# Altium schematic sheet IF_RX.SchDoc
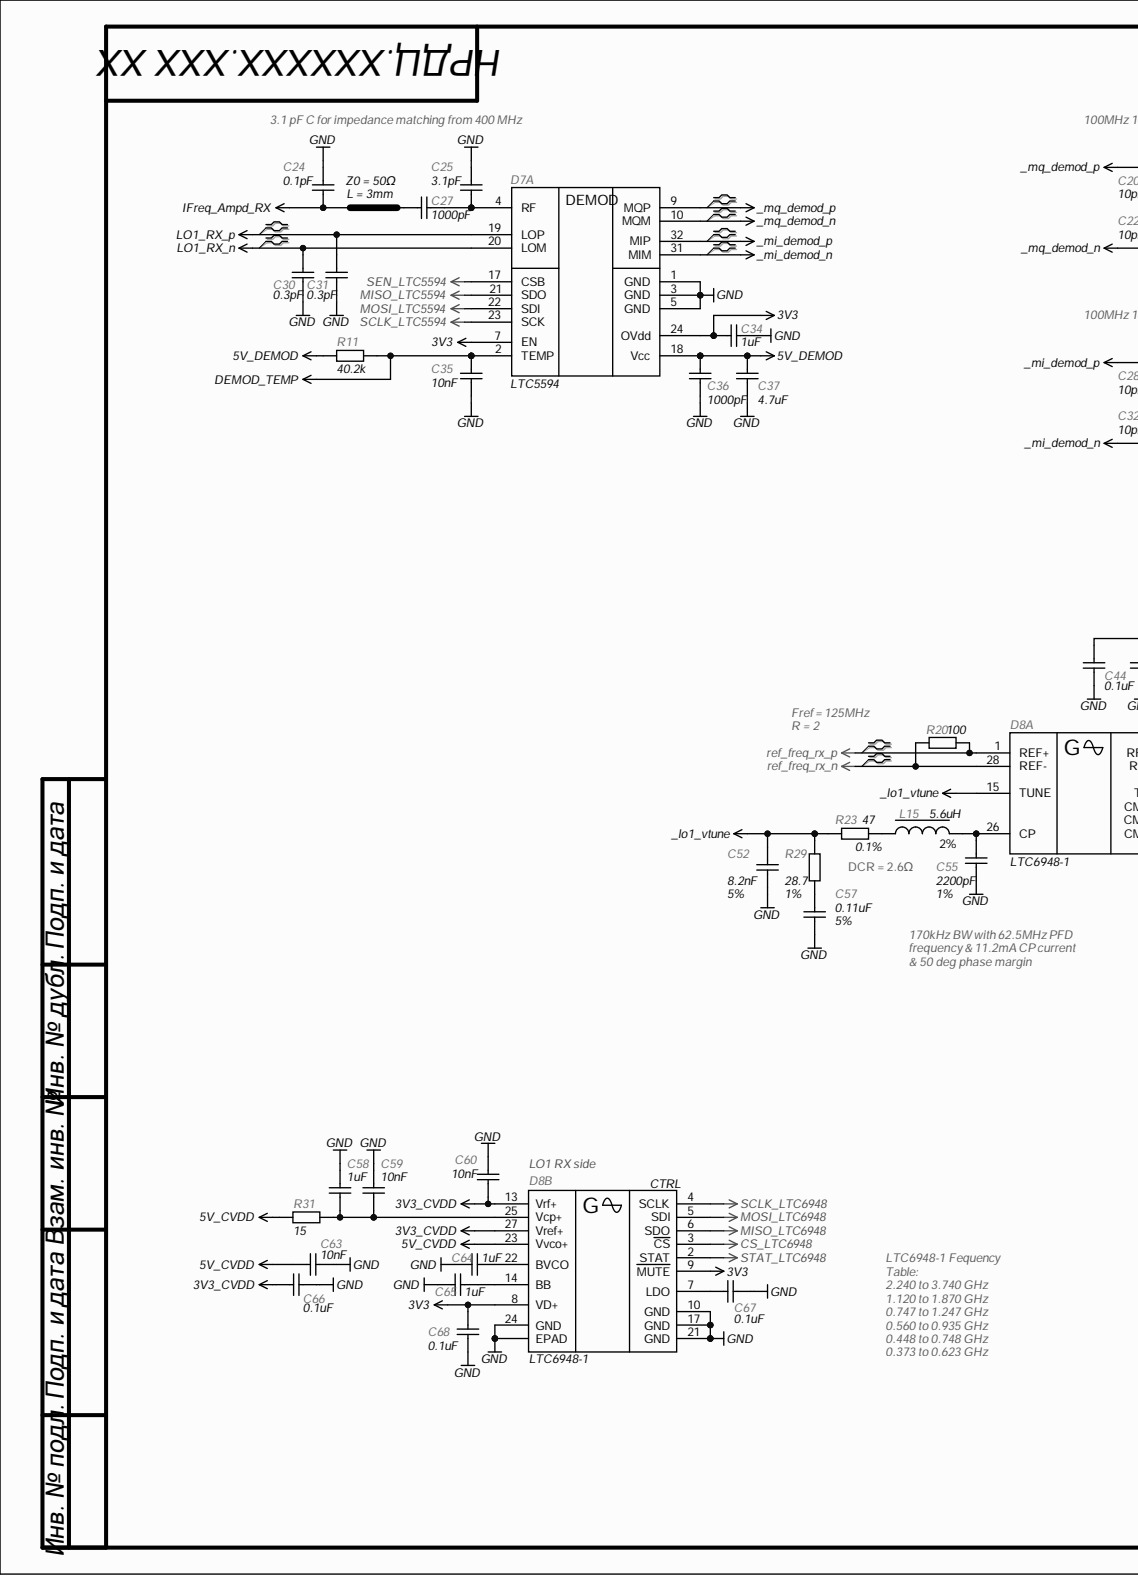
[diagram p0: IF_RX.SchDoc, left]
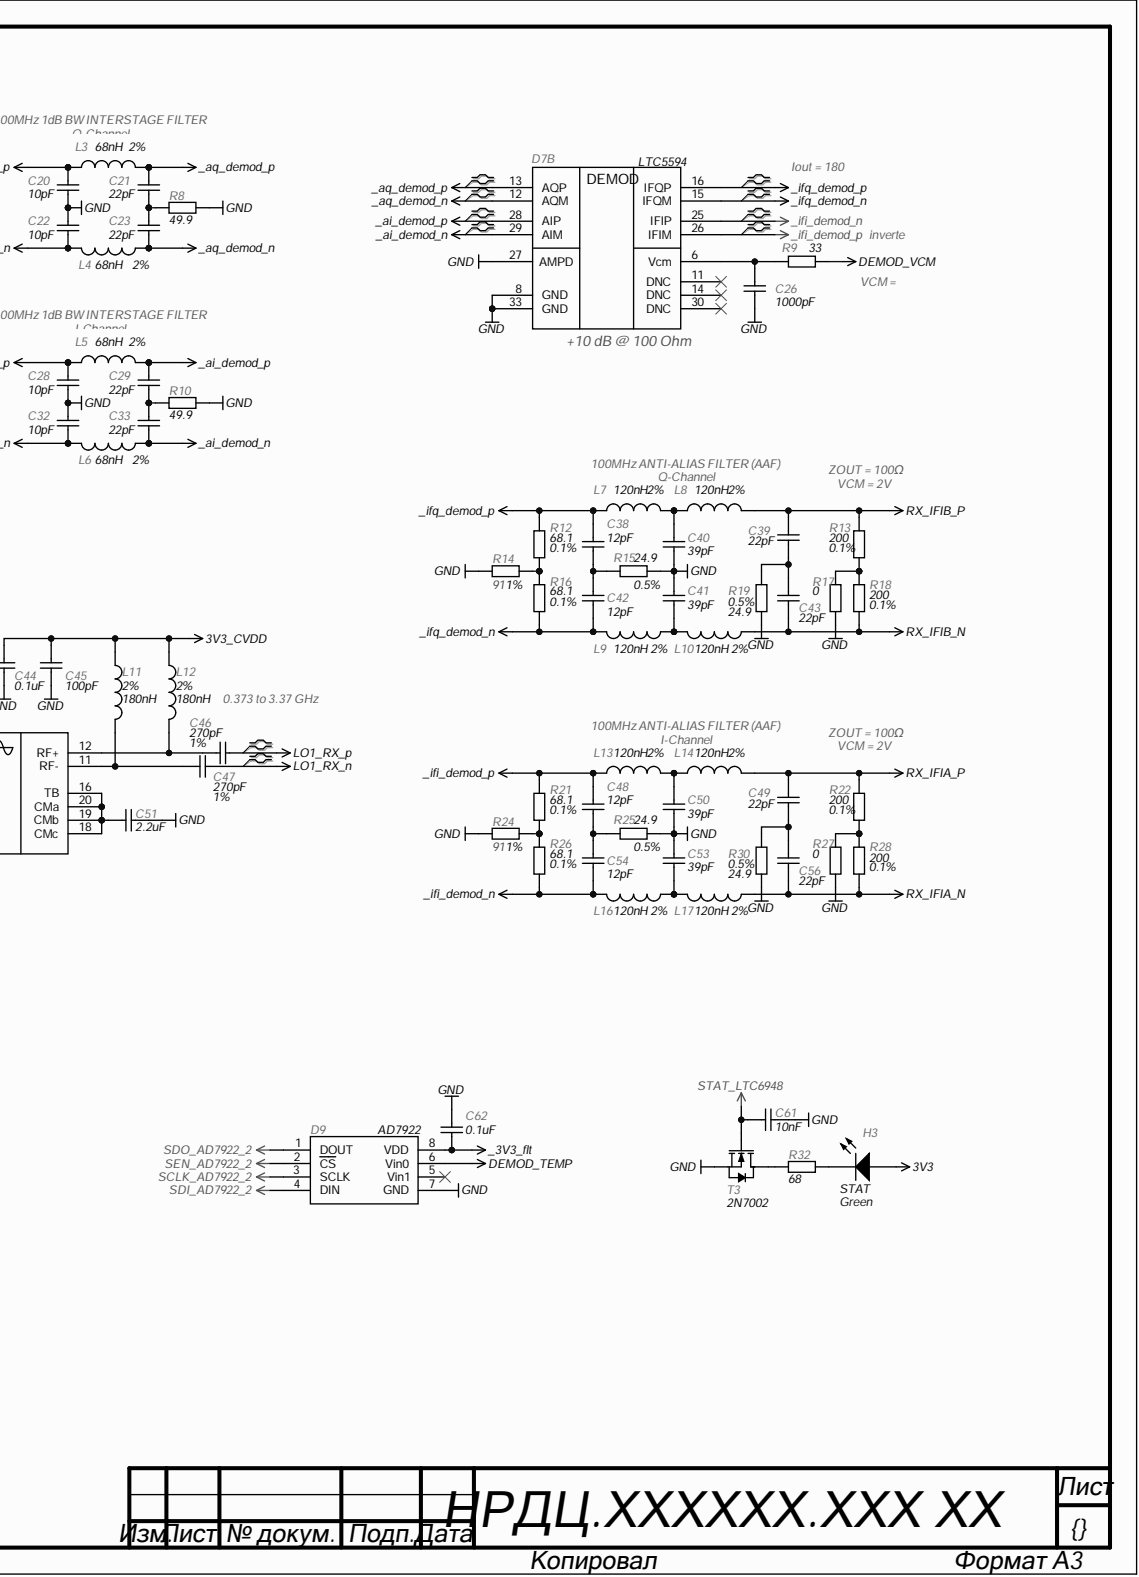
[diagram p1: IF_RX.SchDoc, right]

## Parts
C20  value 10pF  [C0402]  (hidden comment: CAP)
C21  value 22pF  [C0402]  (hidden comment: CAP)
C22  value 10pF  [C0402]  (hidden comment: CAP)
C23  value 22pF  [C0402]  (hidden comment: CAP)
C24  value 0.1pF  [C0402]  (hidden comment: CAP)
C25  value 3.1pF  [C0402]  (hidden comment: CAP)
C26  value 1000pF  [C0402]  (hidden comment: CAP)
C27  value 1000pF  [C0402]  (hidden comment: CAP)
C28  value 10pF  [C0402]  (hidden comment: CAP)
C29  value 22pF  [C0402]  (hidden comment: CAP)
C30  value 0.3pF  [C0402]  (hidden comment: CAP)
C31  value 0.3pF  [C0402]  (hidden comment: CAP)
C32  value 10pF  [C0402]  (hidden comment: CAP)
C33  value 22pF  [C0402]  (hidden comment: CAP)
C34  value 1uF  [C0402]  (hidden comment: CAP)
C35  value 10nF  [C0402]  (hidden comment: CAP)
C36  value 1000pF  [C0402]  (hidden comment: CAP)
C37  value 4.7uF  [C0805]  (hidden comment: CAP)
C38  value 12pF  [C0402]  (hidden comment: CAP)
C39  value 22pF  [C0402]  (hidden comment: CAP)
C40  value 39pF  [C0402]  (hidden comment: CAP)
C41  value 39pF  [C0402]  (hidden comment: CAP)
C42  value 12pF  [C0402]  (hidden comment: CAP)
C43  value 22pF  [C0402]  (hidden comment: CAP)
C44  value 0.1uF  [C0402]  (hidden comment: CAP)
C45  value 100pF  [C0402]  (hidden comment: CAP)
C46  value 270pF  Tolerance: 1%  [C0402]  (hidden comment: CAP)
C47  value 270pF  Tolerance: 1%  [C0402]  (hidden comment: CAP)
C48  value 12pF  [C0402]  (hidden comment: CAP)
C49  value 22pF  [C0402]  (hidden comment: CAP)
C50  value 39pF  [C0402]  (hidden comment: CAP)
C51  value 2.2uF  [C0603]  (hidden comment: CAP)
C52  value 8.2nF  Tolerance: 5%  [C0603]  (hidden comment: CAP)
C53  value 39pF  [C0402]  (hidden comment: CAP)
C54  value 12pF  [C0402]  (hidden comment: CAP)
C55  value 2200pF  Tolerance: 1%  [C0603]  (hidden comment: CAP)
C56  value 22pF  [C0402]  (hidden comment: CAP)
C57  value 0.11uF  Tolerance: 5%  [C0805]  (hidden comment: CAP)
C58  value 1uF  [C0402]  (hidden comment: CAP)
C59  value 10nF  [C0402]  (hidden comment: CAP)
C60  value 10nF  [C0402]  (hidden comment: CAP)
C61  value 10nF  [C0402]  (hidden comment: CAP)
C62  value 0.1uF  [C0402]  (hidden comment: CAP)
C63  value 10nF  [C0402]  (hidden comment: CAP)
C64  value 1uF  [C0402]  (hidden comment: CAP)
C65  value 1uF  [C0402]  (hidden comment: CAP)
C66  value 0.1uF  [C0402]  (hidden comment: CAP)
C67  value 0.1uF  [C0402]  (hidden comment: CAP)
C68  value 0.1uF  [C0402]  (hidden comment: CAP)
D7  LTC5594  [QFN-32]  (parts A,B)
D8  LTC6948-1  [QFN-28]  (parts A,B)
D9  AD7922  [MSOP-8]
H3  STAT  Color: Green  [LED_0805_Green]
L3  value 68nH  Tolerance: 2%  [L0402HPH]  (hidden comment: Inductor RF)
L4  value 68nH  Tolerance: 2%  [L0402HPH]  (hidden comment: Inductor RF)
L5  value 68nH  Tolerance: 2%  [L0402HPH]  (hidden comment: Inductor RF)
L6  value 68nH  Tolerance: 2%  [L0402HPH]  (hidden comment: Inductor RF)
L7  value 120nH  Tolerance: 2%  [L0402HPH]  (hidden comment: Inductor RF)
L8  value 120nH  Tolerance: 2%  [L0402HPH]  (hidden comment: Inductor RF)
L9  value 120nH  Tolerance: 2%  [L0402HPH]  (hidden comment: Inductor RF)
L10  value 120nH  Tolerance: 2%  [L0402HPH]  (hidden comment: Inductor RF)
L11  value 180nH  Tolerance: 2%  [L0603_HP]  (hidden comment: Inductor RF)
L12  value 180nH  Tolerance: 2%  [L0603_HP]  (hidden comment: Inductor RF)
L13  value 120nH  Tolerance: 2%  [L0402HPH]  (hidden comment: Inductor RF)
L14  value 120nH  Tolerance: 2%  [L0402HPH]  (hidden comment: Inductor RF)
L15  value 5.6uH  Tolerance: 2%  [L1008_LS]  (hidden comment: Inductor)
L16  value 120nH  Tolerance: 2%  [L0402HPH]  (hidden comment: Inductor RF)
L17  value 120nH  Tolerance: 2%  [L0402HPH]  (hidden comment: Inductor RF)
R8  value 49.9  [R0402]  (hidden comment: RES)
R9  value 33  [R0402]  (hidden comment: RES)
R10  value 49.9  [R0402]  (hidden comment: RES)
R11  value 40.2k  [R0402]  (hidden comment: RES)
R12  value 68.1  Tolerance: 0.1%  [R0402]  (hidden comment: RES)
R13  value 200  Tolerance: 0.1%  [R0402]  (hidden comment: RES)
R14  value 91  Tolerance: 1%  [R0402]  (hidden comment: RES)
R15  value 24.9  Tolerance: 0.5%  [R0402]  (hidden comment: RES)
R16  value 68.1  Tolerance: 0.1%  [R0402]  (hidden comment: RES)
R17  value 0  [R0402]  (hidden comment: RES)
R18  value 200  Tolerance: 0.1%  [R0402]  (hidden comment: RES)
R19  value 24.9  Tolerance: 0.5%  [R0402]  (hidden comment: RES)
R20  value 100  [R0402]  (hidden comment: RES)
R21  value 68.1  Tolerance: 0.1%  [R0402]  (hidden comment: RES)
R22  value 200  Tolerance: 0.1%  [R0402]  (hidden comment: RES)
R23  value 47  Tolerance: 0.1%  [R0402]  (hidden comment: RES)
R24  value 91  Tolerance: 1%  [R0402]  (hidden comment: RES)
R25  value 24.9  Tolerance: 0.5%  [R0402]  (hidden comment: RES)
R26  value 68.1  Tolerance: 0.1%  [R0402]  (hidden comment: RES)
R27  value 0  [R0402]  (hidden comment: RES)
R28  value 200  Tolerance: 0.1%  [R0402]  (hidden comment: RES)
R29  value 28.7  [R0402]  (hidden comment: RES)
R30  value 24.9  Tolerance: 0.5%  [R0402]  (hidden comment: RES)
R31  value 15  [R0603]  (hidden comment: RES)
R32  value 68  [R0402]  (hidden comment: RES)
T3  2N7002  [SOT-23]

## Nets
3V3: C34.1 C68.2 D7.7(EN) D7.24(OVdd) D8.8(VD+) D8.9(MUTE) H3.2
3V3_CVDD: C44.2 C45.2 C60.2 C66.2 D8.13(Vrf+) D8.27(Vref+) L11.2 L12.2
5V_CVDD: C63.1 D8.23(Vvco+) R31.2
5V_DEMOD: C36.1 C37.2 D7.18(Vcc) R11.1
_3V3_flt: C62.1 D9.8(VDD)
_ai_demod_n: C33.2 D7.29(AIM) L6.2
_ai_demod_p: C29.1 D7.28(AIP) L5.2
_aq_demod_n: C23.2 D7.12(AQM) L4.2
_aq_demod_p: C21.1 D7.13(AQP) L3.2
_ifi_demod_n: C54.2 D7.25(IFIP) L16.1 R26.2
_ifi_demod_p: C48.1 D7.26(IFIM) L13.1 R21.1
_ifq_demod_n: C42.2 D7.15(IFQM) L9.1 R16.2
_ifq_demod_p: C38.1 D7.16(IFQP) L7.1 R12.1
_lo1_vtune: C52.2 D8.15(TUNE) R23.2 R29.1
_mi_demod_n: C32.2 D7.31(MIM) L6.1
_mi_demod_p: C28.1 D7.32(MIP) L5.1
_mq_demod_n: C22.2 D7.10(MQM) L4.1
_mq_demod_p: C20.1 D7.9(MQP) L3.1
CS_LTC6948: D8.3(CS)
DEMOD_TEMP: C35.1 D7.2(TEMP) D9.6(Vin0) R11.2
DEMOD_VCM: R9.1
GND: C20.2 C22.1 C24.2 C25.2 C26.2 C28.2 C30.2 C31.2 C32.1 C34.2 C35.2 C36.2 C37.1 C40.2 C41.1 C44.1 C45.1 C50.2 C51.1 C52.1 C53.1 C55.1 C57.1 C58.1 C59.1 C60.1 C61.2 C62.2 C63.2 C64.1 C65.2 C66.1 C67.1 C68.1 D7.1(GND) D7.3(GND) D7.5(GND) D7.8(GND) D7.27(AMPD) D7.33(GND) D8.10(GND) D8.17(GND) D8.21(GND) D8.24(GND) D8.29(EPAD) D9.7(GND) R8.2 R10.2 R14.1 R15.2 R17.2 R19.2 R24.1 R25.2 R27.2 R30.2 T3.2
IFreq_Ampd_RX: C24.1 C27.2
LO1_RX_n: C30.1 C47.2 D7.20(LOM)
LO1_RX_p: C31.1 C46.2 D7.19(LOP)
MISO_LTC5594: D7.21(SDO)
MISO_LTC6948: D8.6(SDO)
MOSI_LTC5594: D7.22(SDI)
MOSI_LTC6948: D8.5(SDI)
ref_freq_rx_n: D8.28(REF-) R20.1
ref_freq_rx_p: D8.1(REF+) R20.2
RX_IFIA_N: C56.2 L17.2 R28.2
RX_IFIA_P: C49.1 L14.2 R22.1
RX_IFIB_N: C43.2 L10.2 R18.2
RX_IFIB_P: C39.1 L8.2 R13.1
SCLK_AD7922_2: D9.3(SCLK)
SCLK_LTC5594: D7.23(SCK)
SCLK_LTC6948: D8.4(SCLK)
SDI_AD7922_2: D9.4(DIN)
SDO_AD7922_2: D9.1(DOUT)
SEN_AD7922_2: D9.2(CS)
SEN_LTC5594: D7.17(CSB)
STAT_LTC6948: C61.1 D8.2(STAT) T3.1
NetC21_2: C21.2 C23.1 R8.1
NetC25_1: C25.1 C27.1 D7.4(RF)
NetC26_1: C26.1 D7.6(Vcm) R9.2
NetC29_2: C29.2 C33.1 R10.1
NetC38_2: C38.2 C42.1 R15.1
NetC39_2: C39.2 C43.1 R19.1
NetC40_1: C40.1 L7.2 L8.1
NetC41_2: C41.2 L9.2 L10.1
NetC46_1: C46.1 D8.12(RF+) L12.1
NetC47_1: C47.1 D8.11(RF-) L11.1
NetC48_2: C48.2 C54.1 R25.1
NetC49_2: C49.2 C56.1 R30.1
NetC50_1: C50.1 L13.2 L14.1
NetC51_2: C51.2 D8.16(TB) D8.18(CMc) D8.19(CMb) D8.20(CMa)
NetC53_2: C53.2 L16.2 L17.1
NetC55_2: C55.2 D8.26(CP) L15.2
NetC57_2: C57.2 R29.2
NetC58_2: C58.2 C59.2 D8.25(Vcp+) R31.1
NetC64_2: C64.2 D8.22(BVCO)
NetC65_1: C65.1 D8.14(BB)
NetC67_2: C67.2 D8.7(LDO)
NetH3_1: H3.1 R32.2
NetL15_1: L15.1 R23.1
NetR12_2: R12.2 R14.2 R16.1
NetR13_2: R13.2 R17.1 R18.1
NetR21_2: R21.2 R24.2 R26.1
NetR22_2: R22.2 R27.1 R28.1
NetR32_1: R32.1 T3.3

Not connected: D7 11(DNC) 14(DNC) 30(DNC); D9 5(Vin1)

## Library data of the parts
C20 C22 C28 C32 = CAP: SMD Capacitor; Manufacturer: Murata; Material: NP0; P/N: GRM1555C1H100FA01D; Tolerance: 1%; Type: Ceramic Capacitor; Voltage: 50V; Component Kind: Standard; Supplier 1: Digi-key; Supplier 2: Mouser; Supplier 3: Farnell; Supplier Part Number 1: 490-6186-1-ND; Supplier Part Number 2: 81-GRM1555C1H100FA1D; Library Name: Kintex_SDR.SchLib
C21 C23 C29 C33 C39 C43 C49 C56 = CAP: SMD Capacitor; Manufacturer: Murata; Material: NP0; P/N: GRM1555C1H220FA01D; Tolerance: 1%; Type: Ceramic Capacitor; Voltage: 50V; Component Kind: Standard; Supplier 1: Digi-key; Supplier 2: Mouser; Supplier 3: Farnell; Supplier Part Number 1: 490-8589-1-ND; Supplier Part Number 2: 81-GRM1555C1H220FA1D; Library Name: Kintex_SDR.SchLib
C24 = CAP: SMD Capacitor; Manufacturer: Murata; Material: NP0; P/N: GRM1555C1HR10WA01D; Tolerance: ±0.05pF; Type: Ceramic Capacitor; Voltage: 50V; Component Kind: Standard; Supplier 1: Digi-key; Supplier 2: Mouser; Supplier 3: Farnell; Supplier Part Number 1: 490-6259-1-ND; Supplier Part Number 2: 81-GRM1555C1HR10WA1D; Library Name: Kintex_SDR.SchLib
C25 = CAP: SMD Capacitor; Manufacturer: Murata; Material: NP0; P/N: GJM1555C1H3R1WB01D; Tolerance: ±0.05pF; Type: Ceramic Capacitor; Voltage: 50V; Component Kind: Standard; Supplier 1: Digi-key; Supplier 2: Mouser; Supplier 3: Farnell; Supplier Part Number 1: 490-GJM1555C1H3R1WB01DCT-ND; Supplier Part Number 2: 81-GJM1555C1H3R1WB1D; Library Name: Kintex_SDR.SchLib
C26 C27 C36 = CAP: SMD Capacitor; Manufacturer: Murata; Material: NP0; P/N: GRM1555C1H102JA01D; Tolerance: 5%; Type: Ceramic Capacitor; Voltage: 50V; Component Kind: Standard; Supplier 1: Digi-key; Supplier 2: Mouser; Supplier 3: Farnell; Supplier Part Number 1: 490-3244-1-ND; Supplier Part Number 2: 81-GRM1555C1H102JA1D; Library Name: Kintex_SDR.SchLib
C30 C31 = CAP: SMD Capacitor; Manufacturer: Murata; Material: NP0; P/N: GRM1555C1HR30WA01D; Tolerance: ±0.05pF; Type: Ceramic Capacitor; Voltage: 50V; Component Kind: Standard; Supplier 1: Digi-key; Supplier 2: Mouser; Supplier 3: Farnell; Supplier Part Number 1: 490-6261-1-ND; Supplier Part Number 2: 81-GRM1555C1HR30WA1D; Library Name: Kintex_SDR.SchLib
C34 C58 C64 C65 = CAP: SMD Capacitor; Manufacturer: Murata; Material: X7R; P/N: GRM155R70J105KA12J; Tolerance: 10%; Type: Ceramic Capacitor; Voltage: 6.3V; Component Kind: Standard; Supplier 1: Digi-key; Supplier 2: Mouser; Supplier 3: Farnell; Supplier Part Number 1: 490-13339-1-ND; Supplier Part Number 2: 81-GRM155R70J105KA2J; Library Name: Kintex_SDR.SchLib
C35 C59-C61 C63 = CAP: SMD Capacitor; Manufacturer: Murata; Material: X7R; P/N: GRM155R71E103KA01D; Tolerance: 10%; Type: Ceramic Capacitor; Voltage: 25V; Component Kind: Standard; Supplier 1: Digi-key; Supplier 2: Mouser; Supplier 3: Farnell; Supplier Part Number 1: 490-1312-1-ND; Supplier Part Number 2: 81-GRM36X103K25; Library Name: Kintex_SDR.SchLib
C37 = CAP: SMD Capacitor; Manufacturer: Murata; Material: X5R; P/N: GRM21BR61H475KE51L; Tolerance: 10%; Type: Ceramic Capacitor; Voltage: 50V; Component Kind: Standard; Supplier 1: Digi-key; Supplier 2: Mouser; Supplier 3: Farnell; Supplier Part Number 1: 490-10751-1-ND; Supplier Part Number 2: 81-GRM21BR61H475KE1L; Library Name: Kintex_SDR.SchLib
C38 C42 C48 C54 = CAP: SMD Capacitor; Manufacturer: Murata; Material: NP0; P/N: GRM1555C1H120FA01D; Tolerance: 1%; Type: Ceramic Capacitor; Voltage: 50V; Component Kind: Standard; Supplier 1: Digi-key; Supplier 2: Mouser; Supplier 3: Farnell; Supplier Part Number 1: 490-6196-1-ND; Supplier Part Number 2: 81-GRM1555C1H120FA1D; Library Name: Kintex_SDR.SchLib
C40 C41 C50 C53 = CAP: SMD Capacitor; Manufacturer: Murata; Material: NP0; P/N: GRM1555C1H390FA01D; Tolerance: 1%; Type: Ceramic Capacitor; Voltage: 50V; Component Kind: Standard; Supplier 1: Digi-key; Supplier 2: Mouser; Supplier 3: Farnell; Supplier Part Number 1: 490-9663-1-ND; Supplier Part Number 2: 81-GRM1555C1H390FA1D; Library Name: Kintex_SDR.SchLib
C44 C62 C66-C68 = CAP: SMD Capacitor; Manufacturer: Murata; Material: X7R; P/N: GRM155R71A104KA01J; Tolerance: 10%; Type: Ceramic Capacitor; Voltage: 10V; Component Kind: Standard; Supplier 1: Digi-key; Supplier 2: Mouser; Supplier 3: Farnell; Supplier Part Number 1: 490-6322-1-ND; Supplier Part Number 2: 81-GRM155R71A104KA1J; Library Name: Kintex_SDR.SchLib
C45 = CAP: SMD Capacitor; Manufacturer: Murata; Material: NP0; P/N: GRM1555C1H101FA01D; Tolerance: 1%; Type: Ceramic Capacitor; Voltage: 50V; Component Kind: Standard; Supplier 1: Digi-key; Supplier 2: Mouser; Supplier 3: Farnell; Supplier Part Number 1: 490-8180-1-ND; Supplier Part Number 2: 81-GRM1555C1H101FA1D; Library Name: Kintex_SDR.SchLib
C46 C47 = CAP: SMD Capacitor; Manufacturer: Murata; Material: NP0; P/N: GRM1555C1H271FA01D; Type: Ceramic Capacitor; Voltage: 50V; Component Kind: Standard; Supplier 1: Digi-key; Supplier 2: Mouser; Supplier 3: Farnell; Supplier Part Number 1: 490-10686-1-ND; Supplier Part Number 2: 81-GRM1555C1H271FA1D; Library Name: Kintex_SDR.SchLib
C51 = CAP: SMD Capacitor; Manufacturer: Murata; Material: X7R; P/N: GRM188R71A225KE15J; Tolerance: 10%; Type: Ceramic Capacitor; Voltage: 10V; Component Kind: Standard; Supplier 1: Digi-key; Supplier 2: Mouser; Supplier 3: Farnell; Supplier Part Number 1: 490-6418-1-ND; Supplier Part Number 2: 81-GRM188R71A225KE5J; Library Name: Kintex_SDR.SchLib
C52 = CAP: SMD Capacitor; Manufacturer: Murata; Material: NP0; P/N: GRM1885C1H822JA01D; Type: Ceramic Capacitor; Voltage: 50V; Component Kind: Standard; Supplier 1: Digi-key; Supplier 2: Mouser; Supplier 3: Farnell; Supplier Part Number 1: 490-11482-1-ND; Supplier Part Number 2: 81-GRM1885C1H822JA1D; Library Name: Kintex_SDR.SchLib
C55 = CAP: SMD Capacitor; Manufacturer: Murata; Material: NP0; P/N: GCM1885C1H222FA16D; Type: Ceramic Capacitor; Voltage: 50V; Component Kind: Standard; Supplier 1: Digi-key; Supplier 2: Mouser; Supplier 3: Farnell; Supplier Part Number 1: 490-16421-1-ND; Supplier Part Number 2: 81-GCM1885C1H222FA6D; Library Name: Kintex_SDR.SchLib
C57 = CAP: SMD Capacitor; Manufacturer: Murata; Material: NP0; P/N: GRM2195C1H113JA01D; Type: Ceramic Capacitor; Voltage: 50V; Component Kind: Standard; Supplier 1: Digi-key; Supplier 2: Mouser; Supplier 3: Farnell; Supplier Part Number 1: 490-8297-1-ND; Supplier Part Number 2: 81-GRM2195C1H113JA1D; Library Name: Kintex_SDR.SchLib
D7 = LTC5594: Quadrature Demodulator; Manufacturer: Analog Devices; P/N: LTC5594IUH#PBF; Type: High Linearity  I/Q Demodulator; Component Kind: Standard; Library Name: DBLib.SchLib; Name: LTC5594; Package: 32-Lead 5mm × 5mm QFN; Supplier 1: Digi-Key; Supplier 2: Mouser; Supplier 3: Farnell; Supplier Part Number 1: LTC5594IUH#TRPBF-ND; Supplier Part Number 2: 584-LTC5594IUHPBF; Supplier Part Number 3: 4034017; Temperature Range: –40°C to 125°C
D8 = LTC6948: The LTC®LTC6948 is a high performance, low noise, 6.39GHz  phase-locked loop (PLL); Manufacturer: Linear Technology; P/N: LTC6948IUFD-1; Type: Clock/Frequency Synthesizer (RF/IF), Integer N; Voltage: 3.15 V ~ 5.25 V; Component Kind: Standard; Library Name: DBLib.SchLib; Name: LTC6948-1; Package: 28-QFN (4x5); Supplier 1: Digi-Key; Supplier 2: Mouser; Supplier 3: Farnell; Supplier Part Number 1: 505-LTC6948IUFD-1#TRPBFCT-ND; Supplier Part Number 2: 584-LTC6948IUFD-1PBF; Temperature Range: -40°C ~ 105°C
D9 = AD79x2: 1 MSPS, 10-/12-Bit ADC; Manufacturer: Analog Device; Fast throughput rate: 1MHz; High speed serial interface: SPI®/QSPI™/MICROWIRE™/DSP compatible; Name: AD7922ARM; P/N: AD7922ARMZ; Type: 2-Channel, 2.35 V to 5.25 V,1 MSPS, 10-/12-Bit ADC; Voltage: 2.35 V to 5.25 V; Component Kind: Standard; Package: MSOP-8; Supplier 1: Digi-key; Supplier 2: Mouser; Supplier 3: Farnell; Supplier Part Number 1: 505-AD7922ARMZ-ND; Supplier Part Number 2: 584-AD7922ARMZ; Value: AD7922; Library Name: Kintex_SDR.SchLib
H3 = LED: CHIP LED LAMP; Manufacturer: Kingbright; Name: APHCM2012; P/N: APHCM2012CGCK-F01; Component Kind: Standard; Library Name: DBLib.SchLib; Package: 2.0 x 1.25 mm SMD; Supplier 1: Digi-Key; Supplier 2: Mouser; Supplier 3: Farnell; Supplier Part Number 1: 754-1094-1-ND; Supplier Part Number 2: 604-APHCM2012CGCK; Type: CHIP LED LAMP
L3-L6 = Inductor RF: Chip SMD Inductor; Manufacturer: Coilcraft; Current: 320mA; Frequency: 1.84GHz; Name: 0402HPH-68NXGL; P/N: 0402HPH-68NXGLW; Component Kind: Standard; Library Name: DBLib.SchLib; Series: 0402HP; Supplier 1: Digi-key; Supplier 2: Mouser; Supplier 3: Farnell; Supplier Part Number 2: 994-0402HPH-68NXGLW; Supplier Part Number 3: 2285859; Temperature Range: -25…85°C
L7-L10 L13 L14 L16 L17 = Inductor RF: Chip SMD Inductor; Manufacturer: Coilcraft; Current: 310mA; Frequency: 1.25GHz; Name: 0402HPH-R12XGL; P/N: 0402HPH-R12XGLW; Component Kind: Standard; Library Name: DBLib.SchLib; Series: 0402HP; Supplier 1: Digi-key; Supplier 2: Mouser; Supplier 3: Farnell; Supplier Part Number 2: 994-0402HPH-R12XGLW; Supplier Part Number 3: 2285863; Temperature Range: -25…85°C
L11 L12 = Inductor RF: Chip SMD Inductor; Manufacturer: Coilcraft; Current: 310mA; Frequency: 1.5GHz; Name: 0603HP-R18XG; P/N: 0603HP-R18XGL; Component Kind: Standard; Library Name: DBLib.SchLib; Series: 0603HP; Supplier 1: Digi-key; Supplier 2: Mouser; Supplier 3: Farnell; Supplier Part Number 2: 994-0603HP-R18XGLW; Supplier Part Number 3: 2286173; Temperature Range: -25…85°C
L15 = Inductor: Chip SMD Inductor; Manufacturer: Coilcraft; Current: 240mA; DCR: 1.82Ω; Frequency: 32MHz; Name: 1008LS; P/N: 1008LS-562XJLC; Component Kind: Standard; Library Name: DBLib.SchLib; Series: 1008LS; Supplier 1: Digi-key; Supplier 2: Mouser; Supplier 3: Farnell; Supplier Part Number 2: 994-1008LS-562XJLC; Supplier Part Number 3: 2286847; Temperature Range: -25…85°C
R8 R10 = RES: SMD Resistor; Manufacturer: Panasonic Electronic Components; Name: Chip SMD Resistor; P/N: ERJ-2RKD49R9X; Power: 0.063 Wt; Tolerance: 0.5%; Component Kind: Standard; Supplier 1: Digi-key; Supplier 2: Mouser; Supplier 3: Farnell; Supplier Part Number 1: P15987CT-ND; Supplier Part Number 2: 667-ERJ-2RKD49R9X; Temperature Range: -55…155°C; Library Name: Kintex_SDR.SchLib
R9 = RES: SMD Resistor; Name: Chip SMD Resistor; P/N: ERJ-2RKF33R0X; Power: 0.1 Wt; Tolerance: 1%; Component Kind: Standard; Supplier 1: Digi-key; Supplier 2: Mouser; Supplier 3: Farnell; Supplier Part Number 1: P33.0LCT-ND; Supplier Part Number 2: 667-ERJ-2RKF33R0X; Temperature Range: -55…155°C; Library Name: Kintex_SDR.SchLib
R11 = RES: SMD Resistor; Manufacturer: Panasonic Electronic Components; Name: Chip SMD Resistor; P/N: ERJ-2RKF4022X; Power: 0.063 Wt; Tolerance: 1%; Component Kind: Standard; Supplier 1: Digi-key; Supplier 2: Mouser; Supplier 3: Farnell; Supplier Part Number 1: P40.2KLCT-ND; Supplier Part Number 2: 667-ERJ-2RKF4022X; Temperature Range: -55…155°C; Library Name: Kintex_SDR.SchLib
R12 R16 R21 R26 = RES: SMD Resistor; Manufacturer: Panasonic Electronic Components; Name: Chip SMD Resistor; P/N: ERA-2AEB68R1X; Power: 0.063 Wt; Component Kind: Standard; Supplier 1: Digi-key; Supplier 2: Mouser; Supplier 3: Farnell; Supplier Part Number 1: P68.1DCCT-ND; Supplier Part Number 2: 667-ERA-2AEB68R1X; Temperature Range: -55…155°C; Library Name: Kintex_SDR.SchLib
R13 R18 R22 R28 = RES: SMD Resistor; Manufacturer: Panasonic Electronic Components; Name: Chip SMD Resistor; P/N: ERA-2AEB201X; Power: 0.063 Wt; Component Kind: Standard; Supplier 1: Digi-key; Supplier 2: Mouser; Supplier 3: Farnell; Supplier Part Number 1: P200DCCT-ND; Supplier Part Number 2: 667-ERA-2AEB201X; Temperature Range: -55…155°C; Library Name: Kintex_SDR.SchLib
R14 R24 = RES: SMD Resistor; Manufacturer: Panasonic Electronic Components; Name: Chip SMD Resistor; P/N: ERJ-PA2F91R0X; Power: 1/5 Wt; Component Kind: Standard; Supplier 1: Digi-key; Supplier 2: Mouser; Supplier 3: Farnell; Supplier Part Number 1: P124516CT-ND; Supplier Part Number 2: 667-ERJ-PA2F91R0X; Temperature Range: -55…155°C; Library Name: Kintex_SDR.SchLib
R15 R19 R25 R30 = RES: SMD Resistor; Manufacturer: Panasonic Electronic Components; Name: Chip SMD Resistor; P/N: ERJ-2RKD24R9X; Power: 0.063 Wt; Component Kind: Standard; Supplier 1: Digi-key; Supplier 2: Mouser; Supplier 3: Farnell; Supplier Part Number 2: 667-ERJ-2RKD24R9X; Temperature Range: -55…155°C; Library Name: Kintex_SDR.SchLib
R17 R27 = RES: SMD Resistor; Name: Chip SMD Resistor; P/N: ERJ-2GE0R00X; Power: 0.1 Wt; Tolerance: 1%; Component Kind: Standard; Supplier 1: Digi-key; Supplier 2: Mouser; Supplier 3: Farnell; Supplier Part Number 1: P0.0JCT-ND; Supplier Part Number 2: 667-ERJ-2GE0R00X; Temperature Range: -55…155°C; Library Name: Kintex_SDR.SchLib
R20 = RES: SMD Resistor; Manufacturer: Panasonic Electronic Components; Name: Chip SMD Resistor; P/N: ERJ-2GEJ101X; Power: 0.062 Wt; Tolerance: 5%; Component Kind: Standard; Supplier 1: Digi-key; Supplier 2: Mouser; Supplier 3: Farnell; Supplier Part Number 1: P100JCT-ND; Supplier Part Number 2: 667-ERJ-2GEJ101X; Temperature Range: -55…155°C; Library Name: Kintex_SDR.SchLib
R23 = RES: SMD Resistor; Manufacturer: Panasonic Electronic Components; Name: Chip SMD Resistor; P/N: ERA-2AEB470X; Power: 0.2 Wt; Component Kind: Standard; Supplier 1: Digi-key; Supplier 2: Mouser; Supplier 3: Farnell; Supplier Part Number 1: P47DCCT-ND; Supplier Part Number 2: 667-ERA-2AEB470X; Temperature Range: -55…155°C; Library Name: Kintex_SDR.SchLib
R29 = RES: SMD Resistor; Manufacturer: Panasonic Electronic Components; Name: Chip SMD Resistor; P/N: ERJ-2RKF28R7X; Power: 0.2 Wt; Tolerance: 1%; Component Kind: Standard; Supplier 1: Digi-key; Supplier 2: Mouser; Supplier 3: Farnell; Supplier Part Number 1: P28.7LCT-ND; Temperature Range: -55…155°C; Library Name: Kintex_SDR.SchLib
R31 = RES: SMD Resistor; Name: Chip SMD Resistor; P/N: ERJ-3EKF15R0V; Power: 0.1 Wt; Tolerance: 1%; Component Kind: Standard; Supplier 1: Digi-key; Supplier 2: Mouser; Supplier 3: Farnell; Supplier Part Number 1: P15.0HCT-ND; Supplier Part Number 2: 667-ERJ-3EKF15R0V; Temperature Range: -55…155°C; Library Name: Kintex_SDR.SchLib
R32 = RES: SMD Resistor; Name: Chip SMD Resistor; P/N: ERJ-2GEJ680X; Power: 0.062 Wt; Tolerance: 5%; Component Kind: Standard; Supplier 1: Digi-key; Supplier 2: Mouser; Supplier 3: Farnell; Supplier Part Number 1: P68JCT-ND; Supplier Part Number 2: 667-ERJ-2GEJ680X; Temperature Range: -55…155°C; Library Name: Kintex_SDR.SchLib
T3 = 2N7002: N-Channel MOSFET 2N7002; Channel Resistance: 5Ohm; Current: 300mA; P/N: 2N7002NXAKR; Power Dissipation: 0.83W; Voltage: 60V; Component Kind: Standard; Name: 2N7002; Package: SOT-23; Supplier 1: Digi-key; Supplier 2: Mouser; Supplier 3: Farnell; Supplier Part Number 1: 1727-8643-1-ND; Supplier Part Number 2: 771-2N7002NXAKR; Type: SOT-23; Value: 2N7002; Library Name: Kintex_SDR.SchLib
